# Altium schematic sheet waterlevelindicator.SchDoc
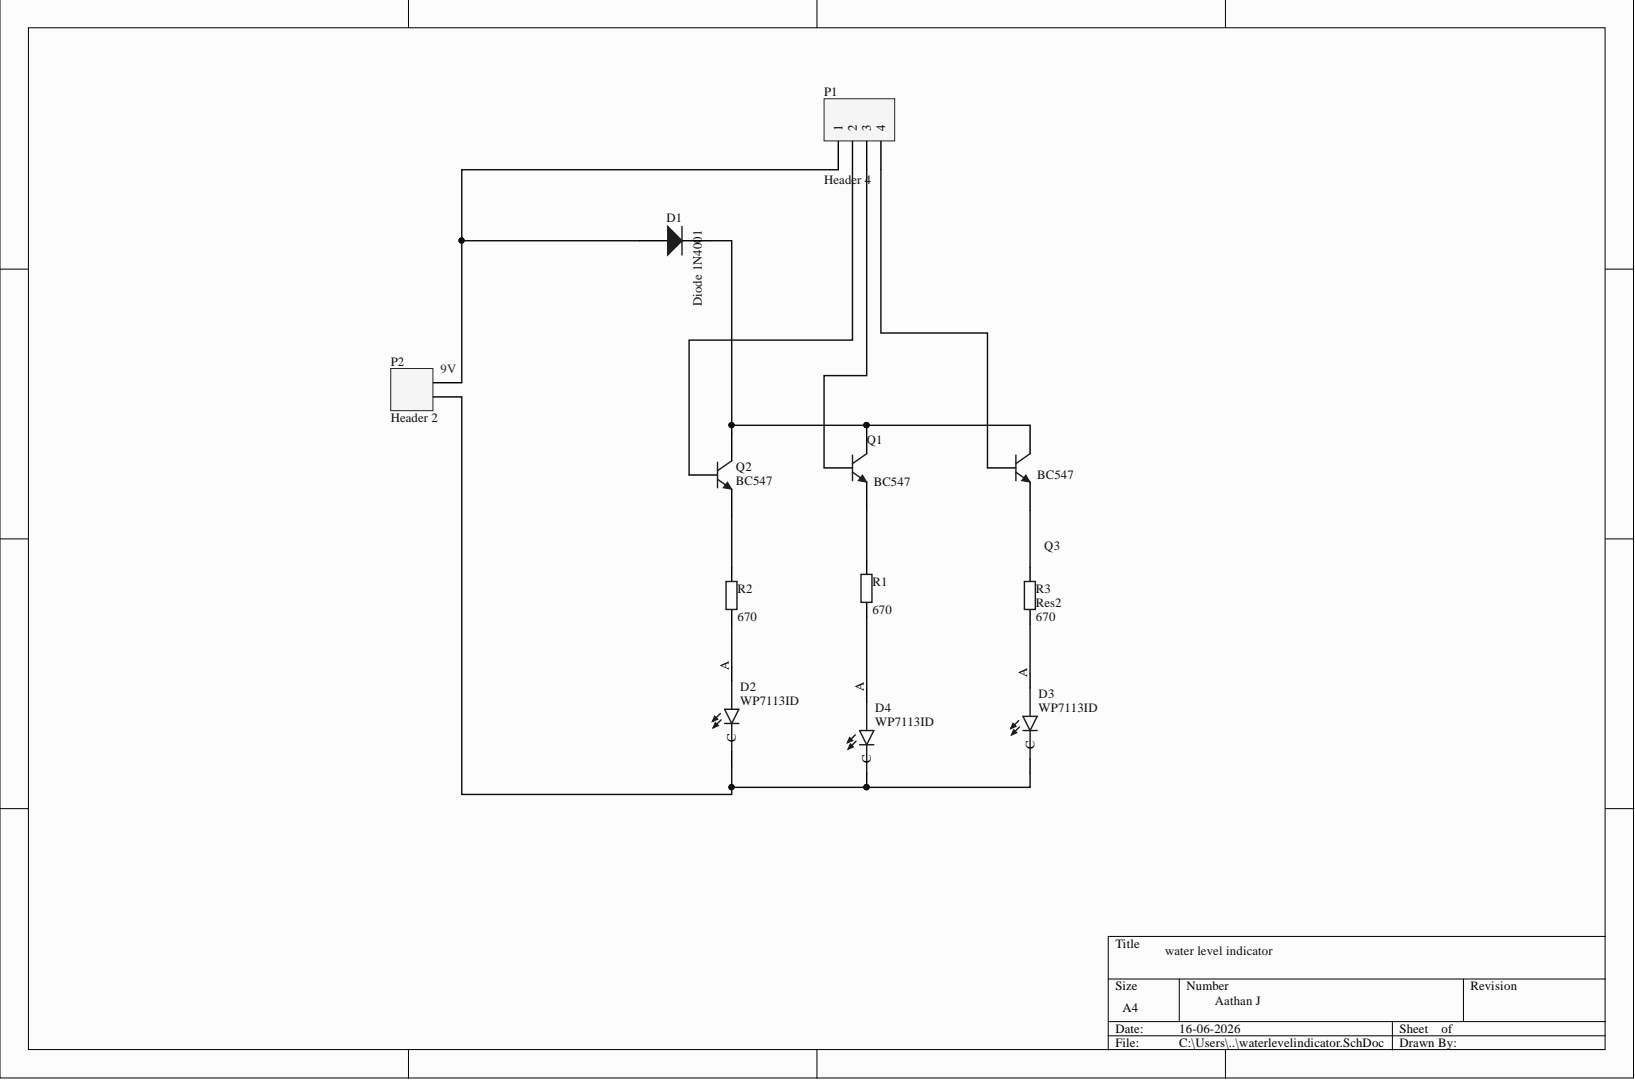
[diagram p0: waterlevelindicator.SchDoc]

## Parts
D1  Diode 1N4001  [DO-41]
D2  WP7113ID  [LED_WP7113ID]
D3  WP7113ID  [LED_WP7113ID]
D4  WP7113ID  [LED_WP7113ID]
P1  Header 4  [HDR1X4]
P2  Header 2  [HDR1X2]
Q1  BC547  [TO-92A]
Q2  BC547  [TO-92A]
Q3  BC547  [TO-92A]
R1  value 670  [AXIAL-0.4]  (hidden comment: Res2)
R2  value 670  [AXIAL-0.4]  (hidden comment: Res2)
R3  Res2  value 670  [AXIAL-0.4]

## Nets
NetD1_1: D1.1 P1.1 P2.2
NetD1_2: D1.2 Q1.3 Q2.3 Q3.3
NetD2_A: D2.A R2.2
NetD2_C: D2.C D3.C D4.C P2.1
NetD3_A: D3.A R3.1
NetD4_A: D4.A R1.1
NetP1_2: P1.2 Q2.2
NetP1_3: P1.3 Q1.2
NetP1_4: P1.4 Q3.2
NetQ1_1: Q1.1 R1.2
NetQ2_1: Q2.1 R2.1
NetQ3_1: Q3.1 R3.2
9V:

## Library data of the parts
D1 = Diode 1N4001: 1 Amp General Purpose Rectifier; Code_JEDEC: DO-204-AL; Component Kind: Standard; ComponentLink1Description: Manufacturer Link; ComponentLink1URL: http://www.fairchildsemi.com/; ComponentLink2Description: Datasheet; ComponentLink2URL: http://www.fairchildsemi.com/ds/1N%2F1N4001.pdf; DatasheetVersion: 1998; LatestRevisionDate: 17-Jul-2002; LatestRevisionNote: Re-released for DXP Platform.; Library Name: Miscellaneous Devices.IntLib; PackageDescription: Diode, Axial; Body 5.2 x 2.7 mm (LxDia. max), Lead Dia. 0.86 mm (max); PackageReference: DO-41; PackageVersion: Aug-1999; Published: 8-Jun-2000; Signal Integrity: 1N4001; Simulation: 1N4001; Publisher: Altium Limited
D2-D4 = WP7113ID: Red 617nm LED Indication - Discrete 2V Radial; MF: Kingbright; Availability: In Stock; Check_prices: https://www.snapeda.com/parts/WP7113ID/Kingbright/view-part/?ref=eda; Component Kind: Standard; Library Name: WP7113ID.IntLib; MP: WP7113ID; Package: Radial Kingbright; Price: None; Purchase-URL: https://pricing.snapeda.com/search/part/WP7113ID/?ref=eda; SnapEDA_Link: https://www.snapeda.com/parts/WP7113ID/Kingbright/view-part/?ref=snap
P1 = Header 4: Header, 4-Pin; Component Kind: Standard; LatestRevisionDate: 17-Jul-2002; LatestRevisionNote: Re-released for DXP Platform.; Library Name: Miscellaneous Connectors.IntLib; Signal Integrity: Connector; Publisher: Altium Limited
P2 = Header 2: Header, 2-Pin; Component Kind: Standard; LatestRevisionDate: 17-Jul-2002; LatestRevisionNote: Re-released for DXP Platform.; Library Name: Miscellaneous Connectors.IntLib; Signal Integrity: Connector; Publisher: Altium Limited
Q1-Q3 = 2N3904: NPN General Purpose Amplifier; Component Kind: Standard; ComponentLink1Description: Manufacturer Link; ComponentLink1URL: http://www.fairchildsemi.com/; ComponentLink2Description: Datasheet; ComponentLink2URL: http://www.fairchildsemi.com/ds/2N%2F2N3904.pdf; DatasheetVersion: 1997; LatestRevisionDate: 15-Jan-2003; LatestRevisionNote: PCB Footprint 'BCY-W3/D4.7' replaced; Library Name: Miscellaneous Devices.IntLib; PackageDescription: TO, Flat Index; 3 In-Line, Axial Leads; Body Dia. 4.5mm; Leads 0.51 x 0.48 mm (max); PackageReference: TO-92A; PackageVersion: Sep-1998; Published: 8-Jun-2000; Signal Integrity: 2N3904; Simulation: 2N3904; Publisher: Altium Limited
R1-R3 = Res2: Resistor; Component Kind: Standard; LatestRevisionDate: 17-Jul-2002; LatestRevisionNote: Re-released for DXP Platform.; Library Name: Miscellaneous Devices.IntLib; PackageDescription: Axial Device, Thru-Hole; 2 Leads; 0.4 in Pin Spacing; PackageReference: AXIAL-0.4; Published: 8-Jun-2000; Signal Integrity: Res; Simulation: RESISTOR; Publisher: Altium Limited
